# Altium schematic sheet Sheet1.SchDoc
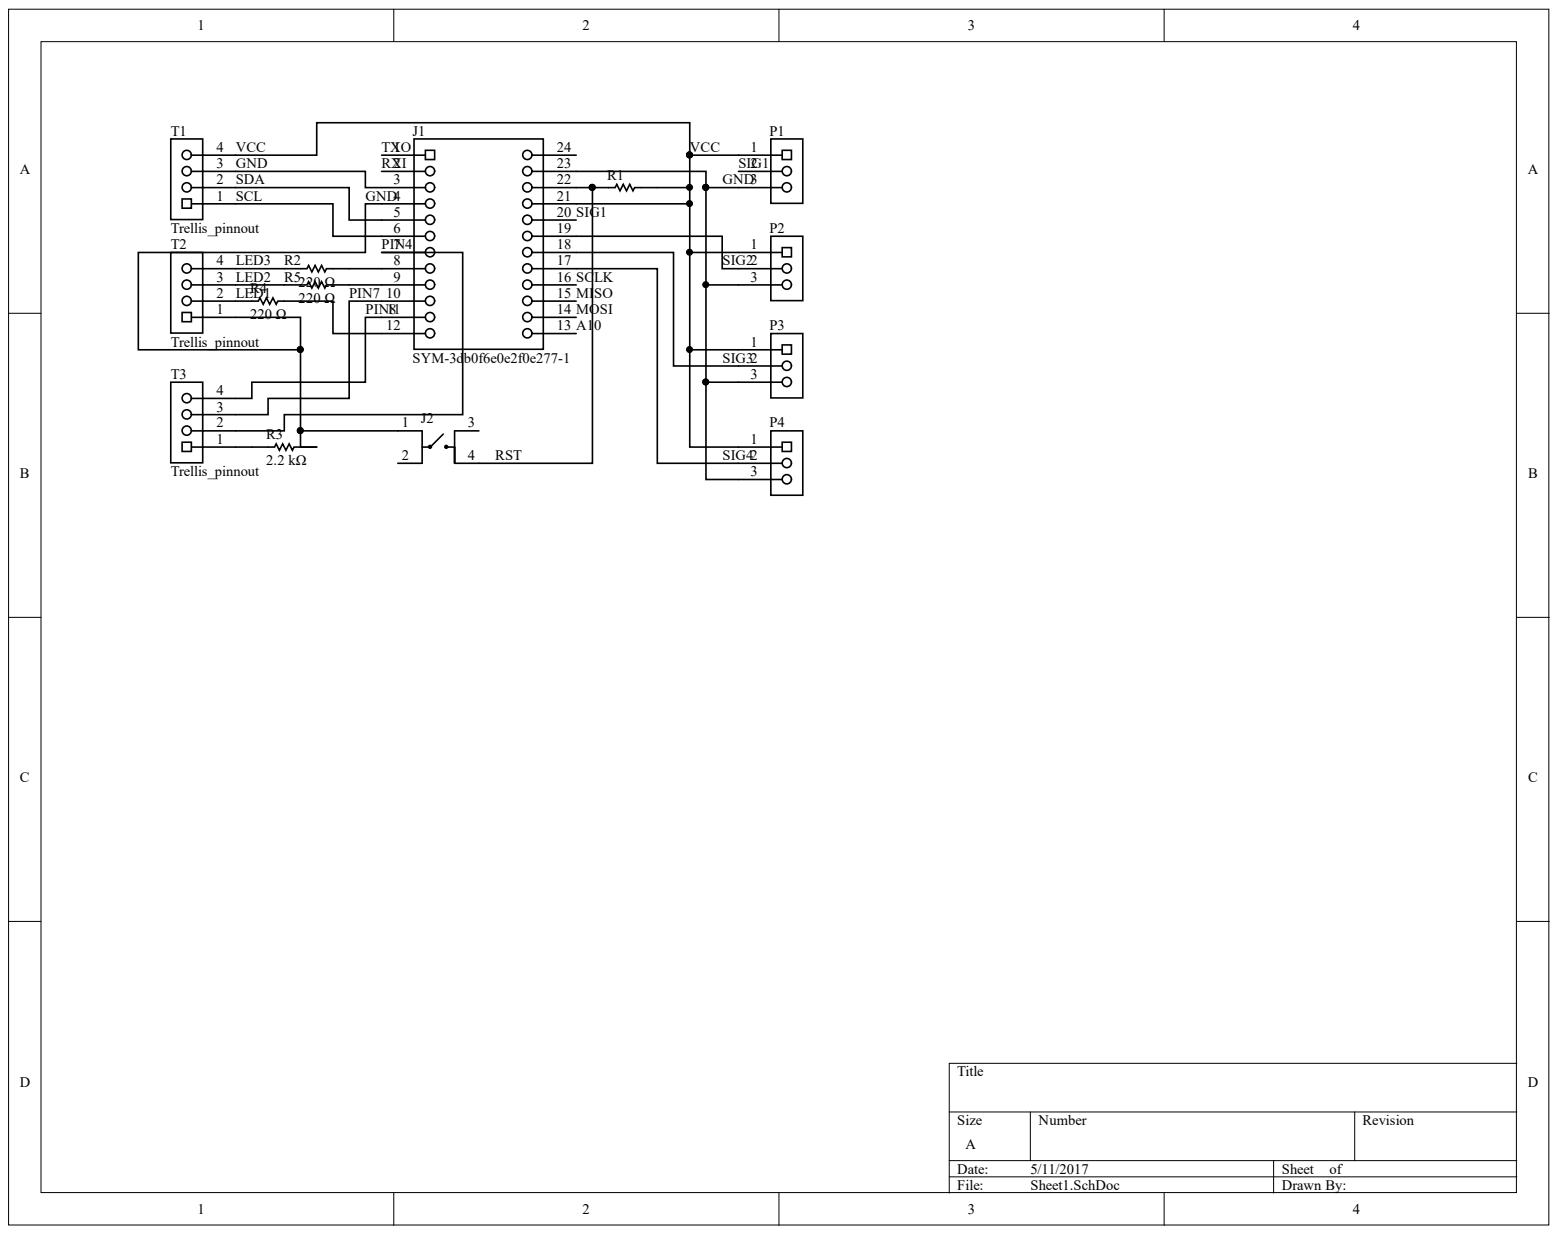
[diagram p0: Sheet1.SchDoc]

## Parts
J1  SYM-3db0f6e0e2f0e277-1  [PCBComponent_1 - duplicate]
J2  TE Connectivity / Alcoswitch FSM8JH  [PCB-nybc64yyco8ubzlhb10m-1]  (value not drawn)
P1  SYM-4yzm57gmqesiynsznqc9-1  [PCB-3xxr40n6as5643nukuwq-1]  (value not drawn)
P2  SYM-4yzm57gmqesiynsznqc9-1  [PCB-3xxr40n6as5643nukuwq-1]  (value not drawn)
P3  SYM-4yzm57gmqesiynsznqc9-1  [PCB-3xxr40n6as5643nukuwq-1]  (value not drawn)
P4  SYM-4yzm57gmqesiynsznqc9-1  [PCB-3xxr40n6as5643nukuwq-1]  (value not drawn)
R1  Vishay MRS25000C1002FCT00  [PCB-9jjzo0xxe8jcobim6tri-1]  (value not drawn)
R2  Resistance: 220 Ω  [PCB-9jjzo0xxe8jcobim6tri-1]  (hidden comment: Vishay MRS25000C1002FCT00)
R3  Resistance: 2.2 kΩ  [PCB-9jjzo0xxe8jcobim6tri-1]  (hidden comment: Vishay MRS25000C1002FCT00)
R4  Resistance: 220 Ω  [PCB-9jjzo0xxe8jcobim6tri-1]  (hidden comment: Vishay MRS25000C1002FCT00)
R5  Resistance: 220 Ω  [PCB-9jjzo0xxe8jcobim6tri-1]  (hidden comment: Vishay MRS25000C1002FCT00)
T1  Trellis_pinnout  [PCB-0tjepb3ta8ig2s3kktsv-1]
T2  Trellis_pinnout  [PCB-0tjepb3ta8ig2s3kktsv-1]
T3  Trellis_pinnout  [PCB-0tjepb3ta8ig2s3kktsv-1]

## Nets
A10: J1.13
GND: J1.3 J1.4 J1.23 J2.1 P1.3 P2.3 P3.3 P4.3 R3.1 T1.3 T2.1
LED1: R4.2 T2.2
LED2: R5.2 T2.3
LED3: R2.2 T2.4
MISO: J1.15
MOSI: J1.14
PIN4: J1.7 T3.2
PIN7: J1.10 T3.3
PIN8: J1.11 T3.4
RST: J1.22 J2.4 R1.1
RXI: J1.2
SCL: J1.6 T1.1
SCLK: J1.16
SDA: J1.5 T1.2
SIG1: J1.20 P1.2
SIG2: J1.19 P2.2
SIG3: J1.18 P3.2
SIG4: J1.17 P4.2
TXO: J1.1
VCC: J1.21 P1.1 P2.1 P3.1 P4.1 R1.2 T1.4
NetJ1_8: J1.8 R2.1
NetJ1_9: J1.9 R5.1
NetJ1_12: J1.12 R4.1
NetR3_2: R3.2 T3.1

Not connected: J1 24; J2 2 3

## Library data of the parts
J1 = CMP-674936-7: AMPMODU Mod II Series 2.54mm 6 Way 1 Row Straight PCB Board to Board; Manufacturer Part Number: 5-534237-4; ELV Compliant: Compliant; Gender: Female; Lead-Free Status: Lead Free; Number of Contacts: 6; Color: Black; Component Kind: Standard; Contact Material: Phosphor Bronze; Contact Plating: Gold, Tin over Nickel; Flammability Rating: UL 94 V-0; Housing Material: Polyester; Library Name: CommunityVault; Lifecycle Status: Active; Mounting Style: Through Hole; Number of Positions: 6; Number of Rows: 1; OctopartID: 3db0f6e0e2f0e277; Octopart URL: http://octopart.com/5-534237-4-te+connectivity+%2F+amp-39516710; Orientation: Vertical; Packaging: Tube; Pin Pitch: 2.54 mm; RoHS: Compliant; Size-Height: 8.64 mm; Size-Length: 15.2 mm; Size-Width: 3.56 mm
J2 = CMP-666aad1cd84eb9ad-1: Switch Tactile OFF (ON) SPST Extended Round Button PC Pins 0.05A 12VDC 1.57N Thru-Hole Loose Piece; Component Kind: Standard; Library Name: CommunityVault; OctopartID: 666aad1cd84eb9ad
P1-P4 = CMP-4yzm57gmqesiynsznqc9-4: potentiometer outputs; Component Kind: Standard; Library Name: CommunityVault
R1 = CMP-a803638309ad77c4-4: Through Hole Metal Film Resistor, MRS 25 Series, 10 kohm, 600 mW, - 1%, 350 V, Axial Leaded; Lead-Free Status: Lead Free; Lead Length: 26.0 mm; Power Rating: 600 mW; Resistance: 10.0 kΩ; Voltage Rating (DC): 350 V; Component Kind: Standard; Library Name: CommunityVault; Manufacturer Part Number: MRS25000C1002FCT00; Number of Pins: 2; OctopartID: a803638309ad77c4; Octopart URL: http://octopart.com/mrs25000c1002fct00-vishay-42632392; REACH SVHC Compliance: No SVHC; Resistance Tolerance: ±1%; RoHS: Compliant; Size-Diameter: 2.50 mm; Size-Length: 6.50 mm; Size-Width: 2.50 mm
R2-R5 = CMP-a803638309ad77c4-4: Through Hole Metal Film Resistor, MRS 25 Series, 10 kohm, 600 mW, - 1%, 350 V, Axial Leaded; Lead-Free Status: Lead Free; Lead Length: 26.0 mm; Power Rating: 600 mW; Voltage Rating (DC): 350 V; Component Kind: Standard; Library Name: CommunityVault; Manufacturer Part Number: MRS25000C1002FCT00; Number of Pins: 2; OctopartID: a803638309ad77c4; Octopart URL: http://octopart.com/mrs25000c1002fct00-vishay-42632392; REACH SVHC Compliance: No SVHC; Resistance Tolerance: ±1%; RoHS: Compliant; Size-Diameter: 2.50 mm; Size-Length: 6.50 mm; Size-Width: 2.50 mm
T1-T3 = CMP-dc655mlpn48s3cv609cg-3: trellis pins; Component Kind: Standard; Designator: T?; Library Name: CommunityVault
